# Altium schematic sheet Logic Backplane.SchDoc("Logic Backplane")
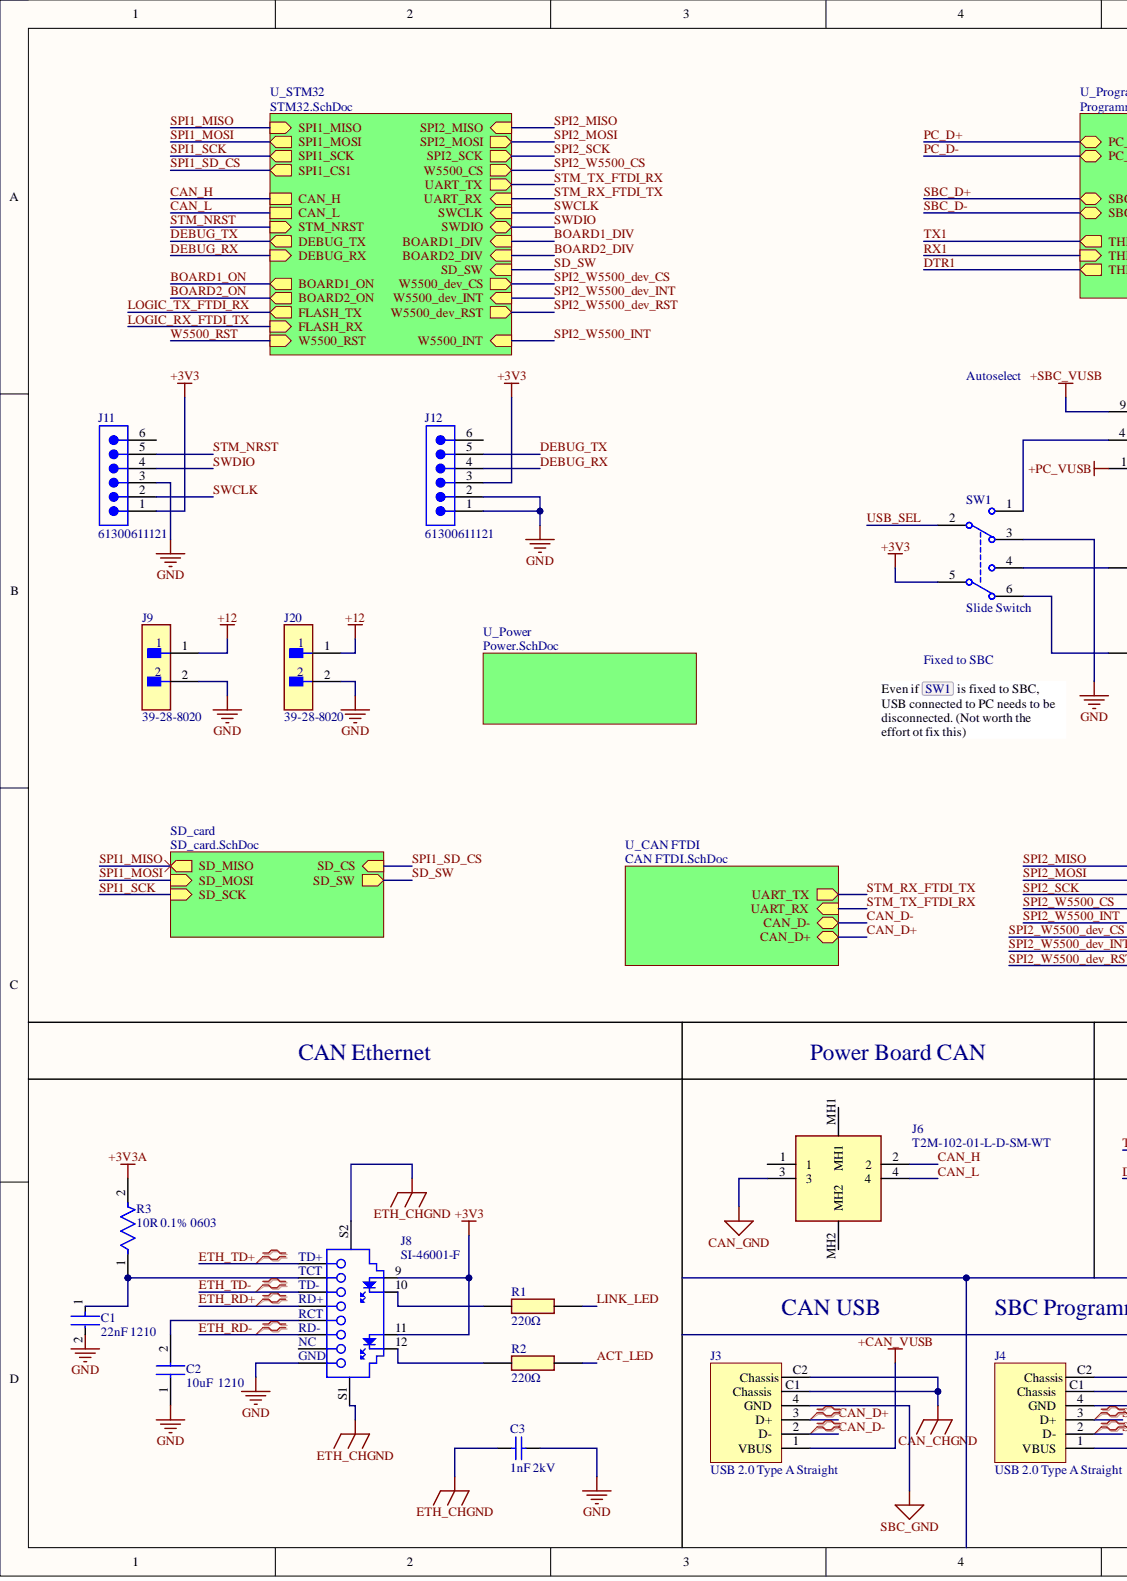
[diagram p0: Logic Backplane.SchDoc, left]
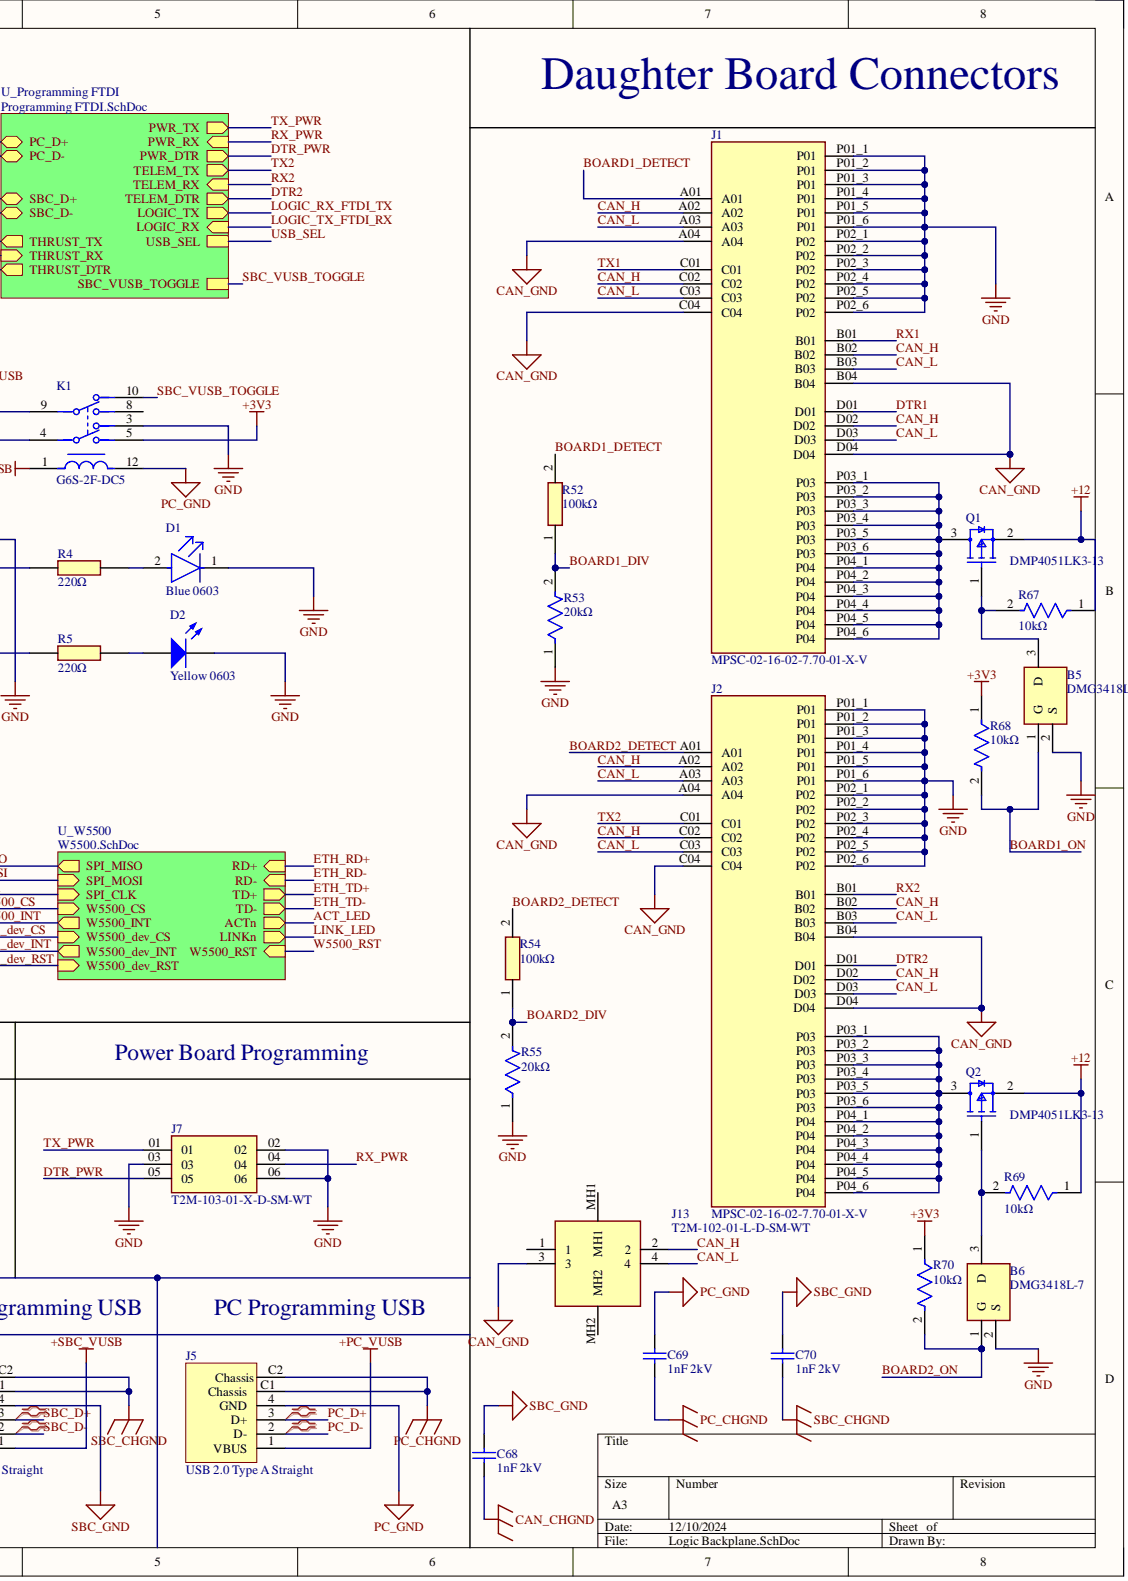
[diagram p1: Logic Backplane.SchDoc, right]

## Parts
B5  DMG3418L-7
B6  DMG3418L-7
C1  22nF 1210  Capacitance: 22nF
C2  10uF  1210
C3  1nF 2kV
C68  1nF 2kV
C69  1nF 2kV
C70  1nF 2kV
D1  Blue 0603  Case Code (Imperial): 0603  Color: Blue
D2  Yellow 0603  Case Code (Imperial): 0603  Color: Yellow
J1  MPSC-02-16-02-7.70-01-X-V
J2  MPSC-02-16-02-7.70-01-X-V
J3  USB 2.0 Type A Straight  Contact Style: USB
J4  USB 2.0 Type A Straight  Contact Style: USB
J5  USB 2.0 Type A Straight  Contact Style: USB
J6  T2M-102-01-L-D-SM-WT
J7  T2M-103-01-X-D-SM-WT
J8  SI-46001-F
J9  39-28-8020
J11  61300611121
J12  61300611121
J13  T2M-102-01-L-D-SM-WT
J20  39-28-8020
K1  G6S-2F-DC5
Q1  DMP4051LK3-13
Q2  DMP4051LK3-13
R1  220Ω
R2  220Ω
R3  10R 0.1% 0603
R4  220Ω
R5  220Ω
R52  100kΩ
R53  20kΩ
R54  100kΩ
R55  20kΩ
R67  10kΩ
R68  10kΩ
R69  10kΩ
R70  10kΩ
SW1  Slide Switch

## Nets
+3V3: J8.9 J8.11 J11.1 J12.3 K1.5 R68.1 R70.1 SW1.5
+3V3A: R3.2
+12: J9.1 J20.1 Q1.2 Q2.2 R67.1 R69.1
+CAN_VUSB: J3.1(VBUS)
+PC_VUSB: J5.1(VBUS) K1.1
+SBC_VUSB: J4.1(VBUS) K1.9
CAN_CHGND: C68.1 J3.C1(Chassis) J3.C2(Chassis)
CAN_GND: J1.A04 J1.B04 J1.C04 J1.D04 J2.A04 J2.B04 J2.C04 J2.D04 J6.3 J13.3
ETH_CHGND: C3.1 J8.S1 J8.S2
GND: B5.2 B6.2 C1.2 C2.1 C3.2 D1.1 D2.1 J1.P01_1(P01) J1.P01_2(P01) J1.P01_3(P01) J1.P01_4(P01) J1.P01_5(P01) J1.P01_6(P01) J1.P02_1(P02) J1.P02_2(P02) J1.P02_3(P02) J1.P02_4(P02) J1.P02_5(P02) J1.P02_6(P02) J2.P01_1(P01) J2.P01_2(P01) J2.P01_3(P01) J2.P01_4(P01) J2.P01_5(P01) J2.P01_6(P01) J2.P02_1(P02) J2.P02_2(P02) J2.P02_3(P02) J2.P02_4(P02) J2.P02_5(P02) J2.P02_6(P02) J7.02 J7.03 J7.06 J8.8 J9.2 J11.3 J12.1 J12.2 J20.2 K1.3 R53.1 R55.1 SW1.3
PC_CHGND: C69.1 J5.C1(Chassis) J5.C2(Chassis)
PC_GND: C69.2 J5.4(GND) K1.12
SBC_CHGND: C70.1 J4.C1(Chassis) J4.C2(Chassis)
SBC_GND: C68.2 C70.2 J3.4(GND) J4.4(GND)
ACT_LED: R2.2
BOARD1_DETECT: J1.A01 R52.2
BOARD1_DIV: R52.1 R53.2
BOARD1_ON: B5.1 R68.2
BOARD2_DETECT: J2.A01 R54.2
BOARD2_DIV: R54.1 R55.2
BOARD2_ON: B6.1 R70.2
CAN_D+: J3.3(D+)
CAN_D-: J3.2(D-)
CAN_H: J1.A02 J1.B02 J1.C02 J1.D02 J2.A02 J2.B02 J2.C02 J2.D02 J6.2 J13.2
CAN_L: J1.A03 J1.B03 J1.C03 J1.D03 J2.A03 J2.B03 J2.C03 J2.D03 J6.4 J13.4
DEBUG_RX: J12.4
DEBUG_TX: J12.5
DTR1: J1.D01
DTR2: J2.D01
DTR_PWR: J7.05
ETH_RD+: J8.4
ETH_RD-: J8.6
ETH_TD+: J8.1
ETH_TD-: J8.3
LINK_LED: R1.2
PC_D+: J5.3(D+)
PC_D-: J5.2(D-)
RX1: J1.B01
RX2: J2.B01
RX_PWR: J7.04
SBC_D+: J4.3(D+)
SBC_D-: J4.2(D-)
SBC_VUSB_TOGGLE: K1.10
STM_NRST: J11.5
SWCLK: J11.2
SWDIO: J11.4
TX1: J1.C01
TX2: J2.C01
TX_PWR: J7.01
USB_SEL: SW1.2
NetB5_3: B5.3 Q1.1 R67.2
NetB6_3: B6.3 Q2.1 R69.2
NetC1_1: C1.1 J8.2 R3.1
NetC2_2: C2.2 J8.5
NetD1_2: D1.2 R4.2
NetD2_2: D2.2 R5.2
NetJ1_P03_1: J1.P03_1(P03) J1.P03_2(P03) J1.P03_3(P03) J1.P03_4(P03) J1.P03_5(P03) J1.P03_6(P03) J1.P04_1(P04) J1.P04_2(P04) J1.P04_3(P04) J1.P04_4(P04) J1.P04_5(P04) J1.P04_6(P04) Q1.3
NetJ2_P03_1: J2.P03_1(P03) J2.P03_2(P03) J2.P03_3(P03) J2.P03_4(P03) J2.P03_5(P03) J2.P03_6(P03) J2.P04_1(P04) J2.P04_2(P04) J2.P04_3(P04) J2.P04_4(P04) J2.P04_5(P04) J2.P04_6(P04) Q2.3
NetJ6_1: J6.1
NetJ6_MH1: J6.MH1
NetJ6_MH2: J6.MH2
NetJ8_7: J8.7
NetJ8_10: J8.10 R1.1
NetJ8_12: J8.12 R2.1
NetJ11_6: J11.6
NetJ12_6: J12.6
NetJ13_1: J13.1
NetJ13_MH1: J13.MH1
NetJ13_MH2: J13.MH2
NetK1_4: K1.4 SW1.1
NetK1_8: K1.8
NetR4_1: R4.1 SW1.4
NetR5_1: R5.1 SW1.6
LOGIC_RX_FTDI_TX:
LOGIC_TX_FTDI_RX:
SD_SW:
SPI1_MISO:
SPI1_MOSI:
SPI1_SCK:
SPI1_SD_CS:
SPI2_MISO:
SPI2_MOSI:
SPI2_SCK:
SPI2_W5500_CS:
SPI2_W5500_dev_CS:
SPI2_W5500_dev_INT:
SPI2_W5500_dev_RST:
SPI2_W5500_INT:
STM_RX_FTDI_TX:
STM_TX_FTDI_RX:
W5500_RST:

## Library data of the parts
B5 B6 = CMP-271-000000-1: Diodes Inc DMG3418L-7 N-channel MOSFET Transistor, 4 A, 30 V, 3-Pin SOT-23; Manufacturer_Name: Diodes Inc.; Geometry.Height: 1.1mm; Component Kind: Standard; Datasheet Link: https://www.diodes.com/assets/Datasheets/DMG3418L.pdf; Design Item ID: DMG3418L-7; Manufacturer_Part_Number: DMG3418L-7; Mouser Part Number: 621-DMG3418L7; Mouser Price/Stock: https://www.mouser.co.uk/ProductDetail/Diodes-Incorporated/DMG3418L-7?qs=JtunSoW0QGFrSn8YFedhPg%3D%3D; Source: DMG3418L-7.SchLib; Library Name: BumbleBee Autonomous Systems - NUS
C1 = CMP-06035-036001-1: Soft Termination Chip Multilayer Ceramic Capacitor, 1210, X7R:EIA, 22000pF, DC630V, AEC, Surface Mount; Dielectric: X7R; Tolerance: 10%; Voltage Rating: 630V; Case Code (Imperial): 1210; Case Code (Metric): 3225; Case/Package: 1210; Component Kind: Standard; Depth: 2.5mm; Features: Soft Termination; Height: 2mm; Length: 3.2mm; Library Name: Altium Content Vault; Max Operating Temperature: 125°C; Min Operating Temperature: -55°C; Mount: Surface Mount; Package Quantity: 1; Packaging: Tape and Reel; Radiation Hardening: No; Ratings: AEC-Q200; REACH SVHC: No SVHC; Termination: SMD/SMT; Thickness: 0.079inch; Voltage Rating (DC): 630V; Width: 2.5mm
C2 = CMP-061-000000-2: Capacitor; Manufacturer_Name: Wurth Elektronik; Manufacturer_Part_Number: 885012208069; Component Kind: Standard; Datasheet Link: https://katalog.we-online.com/pbs/datasheet/885012208069.pdf; Design Item ID: 885012208069; Height: 1.8mm; Mouser Part Number: 710-885012208069; Mouser Price/Stock: https://www.mouser.co.uk/ProductDetail/Wurth-Elektronik/885012208069?qs=0KOYDY2FL2%2FiIl0oJPR7BQ%3D%3D; Source: SamacSys.SchLib; Library Name: BumbleBee Autonomous Systems - NUS
C3 C68-C70 = CMP-00083-2: Ceramic Capacitor, Multilayer, Ceramic, 2000V, 10% +Tol, 10% -Tol, X7R, 15% TC, 0.001uF, Surface Mount, 1210; Component Kind: Standard; Library Name: BumbleBee Autonomous Systems - NUS
D1 = CMP-00012-1: Led, Blue, 470 Nm, 3.2 V, 30 Ma, 145 Mcd Rohs Compliant: Yes; Manufacturer Part No.: 150060BS75000; Dominant Wavelength: 470nm; Forward Current: 30mA; Forward Voltage: 3.2V; Lens Color: Colorless; Lens Size: 0.7mm; Lens Style: Clear,Flat,Rectangular; Lens Transparency: Clear; Luminous Intensity: 145mcd; Test Current: 20mA; Viewing Angle: 140°; Case Code (Metric): 1608; Case/Package: 0603; Component Kind: Standard; Height: 0.8mm; Length: 1.6mm; Max Operating Temperature: 85°C; Min Operating Temperature: -40°C; Mounting Technology: Surface Mount; Package Quantity: 1; Packaging: Cut Tape; Reach SVHC Compliant: Unknown; RoHS Compliant: Yes; Series: WL-SMCW; Wavelength: 470nm; Width: 0.8mm; Library Name: BumbleBee Autonomous Systems - NUS
D2 = CMP-00009-2: Led, Yellow, 590 Nm, 2 V, 30 Ma, 120 Mcd Rohs Compliant: Yes; Manufacturer Part No.: 150060YS75000; Dominant Wavelength: 590nm; Forward Current: 30mA; Forward Voltage: 2V; Lens Style: Clear,Rectangular; Luminous Intensity: 120mcd; Test Current: 20mA; Viewing Angle: 140°; Case Code (Metric): 1608; Case/Package: 0603; Component Kind: Standard; Height: 0.7mm; Length: 1.6mm; Max Operating Temperature: 85°C; Min Operating Temperature: -40°C; Mounting Technology: Surface Mount; Package Quantity: 1; Packaging: Cut Tape; Reach SVHC Compliant: Unknown; RoHS Compliant: Yes; Series: WL-SMCW; Wavelength: 590nm; Width: 0.8mm; Library Name: BumbleBee Autonomous Systems - NUS
J1 J2 = CMP-141-000011-1: Vertical Mini-Power W/ Signal Socket; MF: Samtec; Availability: Unavailable; Component Kind: Standard; Component Type: Standard; Design Item ID: MPSC-02-16-02-7.70-01-X-V; MP: MPSC-02-16-02-7.70-01-X-V; Package: None; Pin Count: 40; Price: None; Source: MPSC-02-16-02-7.70-01-X-V.SchLib; Library Name: BumbleBee Autonomous Systems - NUS
J3-J5 = CMP-141-000005-1: 614004135023, USB Connector, 4 Contact(s), Female, Straight, Solder Terminal, Locking, Receptacle; Board Mounting Option: SOLDERABLE RETENTION CLIPS; Body Breadth: 0.264inch; Body Depth: 0.587inch; Body Length: 0.555inch; Contact Resistance: 20mR; Component Kind: Standard; Library Name: BumbleBee Autonomous Systems - NUS
J6 J13 = CMP-062-000000-1: Connector; Manufacturer_Name: SAMTEC; Component Kind: Standard; Datasheet Link: https://suddendocs.samtec.com/prints/t2m-1xx-xx-x-d-xx-xx-x-xx-mkt.pdf?_gl=1*1h8jfhh*_ga*ODgwNjE2ODg0LjE2NDkxNjE4OTQ.*_ga_3KFNZC07WW*MTY4MDE1NTk5OS4xMjYuMC4xNjgwMTU2MDg2LjYwLjAuMA..; Design Item ID: T2M-102-01-L-D-SM-WT; Height: 6.68mm; Manufacturer_Part_Number: T2M-102-01-L-D-SM-WT; Mouser Part Number: 200-T2M10201LDSMWT; Mouser Price/Stock: https://www.mouser.co.uk/ProductDetail/Samtec/T2M-102-01-L-D-SM-WT?qs=PB6%2FjmICvI1RhUpc5sXmcA%3D%3D; Source: SamacSys.SchLib; Library Name: BumbleBee Autonomous Systems - NUS
J7 = CMP-141-000006-2: Headers & Wire Housings 2.00 mm Tiger Eye PCB Mount Terminal Strip; MF: Samtec; MP: T2M-103-01-L-D-SM-WT; Availability: Bad; Check_prices: https://www.snapeda.com/parts/T2M-103-01-L-D-SM-WT/Samtec/view-part/3435698/?ref=eda; Component Kind: Standard; Component Type: Standard; Design Item ID: T2M-103-01-X-D-SM-WT; Package: None; Pin Count: 6; Price: None; SnapEDA_Link: https://www.snapeda.com/parts/T2M-103-01-L-D-SM-WT/Samtec/view-part/3435698/?ref=snap; Source: T2M-103-01-X-D-SM-WT.SchLib; Library Name: BumbleBee Autonomous Systems - NUS
J8 = CMP-141-000010-1: Telecom and Datacom Connector, 8 Contact(s), Female, Straight, Solder Terminal; Additional Feature: Shielded; Component Kind: Standard; Contact Gender: Female; Library Name: BumbleBee Autonomous Systems - NUS
J9 J20 = CMP-141-000012-1: Rectangular Power Connector, 2 Contact(s), Male, Solder Terminal, Receptacle; Contact Resistance: 10mR; Durability: 30Cycles; Number of Contacts: 2; Component Kind: Standard; Max Operating Temperature: 105°C; Library Name: BumbleBee Autonomous Systems - NUS
J11 J12 = CMP-141-000013-1: Board Connector, 6 Contact(s), 1 Row(s), Male, Straight, 0.1 inch Pitch, Solder Terminal, Locking, Black Insulator, Plug; Number Of Connectors: 1; Number of Contacts: 6; Component Kind: Standard; Design Item ID: 61300611121; Library Name: BumbleBee Autonomous Systems - NUS
K1 = CMP-00071-1: Electromechanical Relay 5VDC 178Ohm 2A DPDT (14.8x9.2x9.2)mm SMD Signal Relay; Component Kind: Standard; Library Name: BumbleBee Autonomous Systems - NUS
Q1 Q2 = CMP-00103-1: Power Field-Effect Transistor, 7.2A I(D), 40V, 0.051ohm, 1-Element, P-Channel, Silicon, Metal-oxide Semiconductor FET, TO-252AA; Case Connection: DRAIN; Drain Current-Max (Abs) (ID): 10.5A; Drain-source On Resistance-Max: 51mR; Number of Elements: 1; Operating Mode: ENHANCEMENT MODE; Package Body Material: Plastic; Power Dissipation-Max (Abs): 8.9W; Pulsed Drain Current-Max (IDM): 28.9A; Qualification Status: Not Qualified; Time @ Peak Reflow Temperature-Max (s): 40s; Transistor Application: SWITCHING; Component Kind: Standard; Drain Current-Max (ID): 7.2A; DS Breakdown Voltage-Min: 40V; FET Technology: METAL-OXIDE SEMICONDUCTOR; JEDEC-95 Code: TO-252AA; JESD-30 Code: R-PSSO-G2; JESD-609 Code: e3; Max Operating Temperature: 150°C; Moisture Sensitivity Level: 1; Package Shape: Rectangular; Package Style: SMALL OUTLINEMeter; Peak Reflow Temperature (Cel): 260°C; Pins: 2; Polarity/Channel Type: P-CHANNEL; Reach SVHC Compliant: No; RoHS Compliant: Yes; Surface Mount: Yes; Terminal Finish: Tin; Terminal Form: Gull Wing; Terminal Position: SINGLE; Transistor Element Material: SILICON; Library Name: BumbleBee Autonomous Systems - NUS
R1 R2 R4 R5 = CMP-147-000013-1: CRCW0805220RFKEBC Res Thick Film 0805 220 Ohm 1% 0.125W(1/8W) +/-100ppm/C Pad SMD T/R; Manufacturer_Name: Vishay; Geometry.Height: 0.5mm; Component Kind: Standard; Datasheet Link: https://www.arrow.com/en/products/crcw0805220rfkebc/vishay; Design Item ID: CRCW0805220RFKEBC; Manufacturer_Part_Number: CRCW0805220RFKEBC; Mouser Part Number: 71-CRCW0805220RFKEBC; Mouser Price/Stock: https://www.mouser.co.uk/ProductDetail/Vishay-Dale/CRCW0805220RFKEBC?qs=wd5RIQLrsJi63lS%252BIhMTzQ%3D%3D; Source: CRCW0805220RFKEBC.SchLib; Library Name: BumbleBee Autonomous Systems - NUS
R3 = CMP-147-000040-1: RP0603BRD0710RL. Thin Film Resistors - SMD 1/10 Wat 10 Ohm 0.1% 0603 AEC-Q200; Component Kind: Standard; Mouser link: https://www.mouser.sg/ProductDetail/YAGEO/RP0603BRD0710RL?qs=sGAEpiMZZMtlubZbdhIBIPTwcdbU5mXWSVMmIwldmEo%3D; Library Name: BumbleBee Autonomous Systems - NUS
R52 R54 = CMP-050-000000-2: Medium Power Thick Film Chip Resistor; Manufacturer_Name: Vishay; Manufacturer_Part_Number: RCC0805100KFKEA; Arrow Part Number: RCC0805100KFKEA; Arrow Price/Stock: https://www.arrow.com/en/products/rcc0805100kfkea/vishay?region=nac; Component Kind: Standard; Datasheet Link: https://www.vishay.com/docs/20066/rcce3.pdf; Design Item ID: RCC0805100KFKEA; Height: 0.5mm; Mouser Part Number: 71-RCC0805100KFKEA; Mouser Price/Stock: https://www.mouser.co.uk/ProductDetail/Vishay-Dale/RCC0805100KFKEA?qs=GedFDFLaBXFnw%2FnoDVfk4A%3D%3D; Source: SamacSys.SchLib; Library Name: BumbleBee Autonomous Systems - NUS
R53 R55 = CMP-147-000032-2: Fixed Resistor, Metal Glaze/thick Film, 0.125W, 20000ohm, 150V, 5% +/-Tol, 200ppm/Cel, Surface Mount, 0805; Manufacturer_Name: Vishay; Manufacturer_Part_Number: CRCW080520K0JNEA; Temperature Coefficient: 200ppm/°C; Value: 20kR; Case/Package: 0805; Component Kind: Standard; Max Operating Temperature: 155°C; Min Operating Temperature: -55°C; Tolerance: 5%; Library Name: BumbleBee Autonomous Systems - NUS
R67-R70 = CMP-00003-1: RT0805FRE0710KL Res Thin Film 0805 10K Ohm 1% 0.125W(1/8W) ±50ppm/°C Pad SMD T/R; Max Power Dissipation: 125mW; Value: 10kR; Voltage Rating: 150V; Case Code (Imperial): 0805; Case Code (Metric): 2012; Case/Package: 0805; Component Kind: Standard; Datasheet: https://www.mouser.sg/datasheet/2/447/PYu_RT_1_to_0_01_RoHS_L_12-3003070.pdf; Height: 0.024inch; Lead Free: Lead Free; Length: 0.079inch; Max Operating Temperature: 125°C; Min Operating Temperature: -55°C; Number of Terminations: 2; Package Quantity: 5000; Packaging: Tape and Reel; Power Rating: 125mW; Reach SVHC Compliant: No; Resistance Tolerance: 1%; Resistor Type: Thin Film; RoHS Compliant: Yes; Series: RT; Technology: THIN FILM; Temperature Coefficient: 50ppm/°C; Termination: SMD/SMT; Tolerance: 1%; Width: 1.25mm; Working Voltage: 150V; Library Name: BumbleBee Autonomous Systems - NUS
SW1 = CMP-209-000002-1: Slide Switch, DPDT, Latched, 0.3A, 6VDC, Solder Terminal, Surface Mount-straight; Manufacturer_Name: Wurth Elektronik; Manufacturer_Part_Number: 452403012014; Component Kind: Standard; Library Name: BumbleBee Autonomous Systems - NUS
